# Altium schematic sheet charger.SchDoc
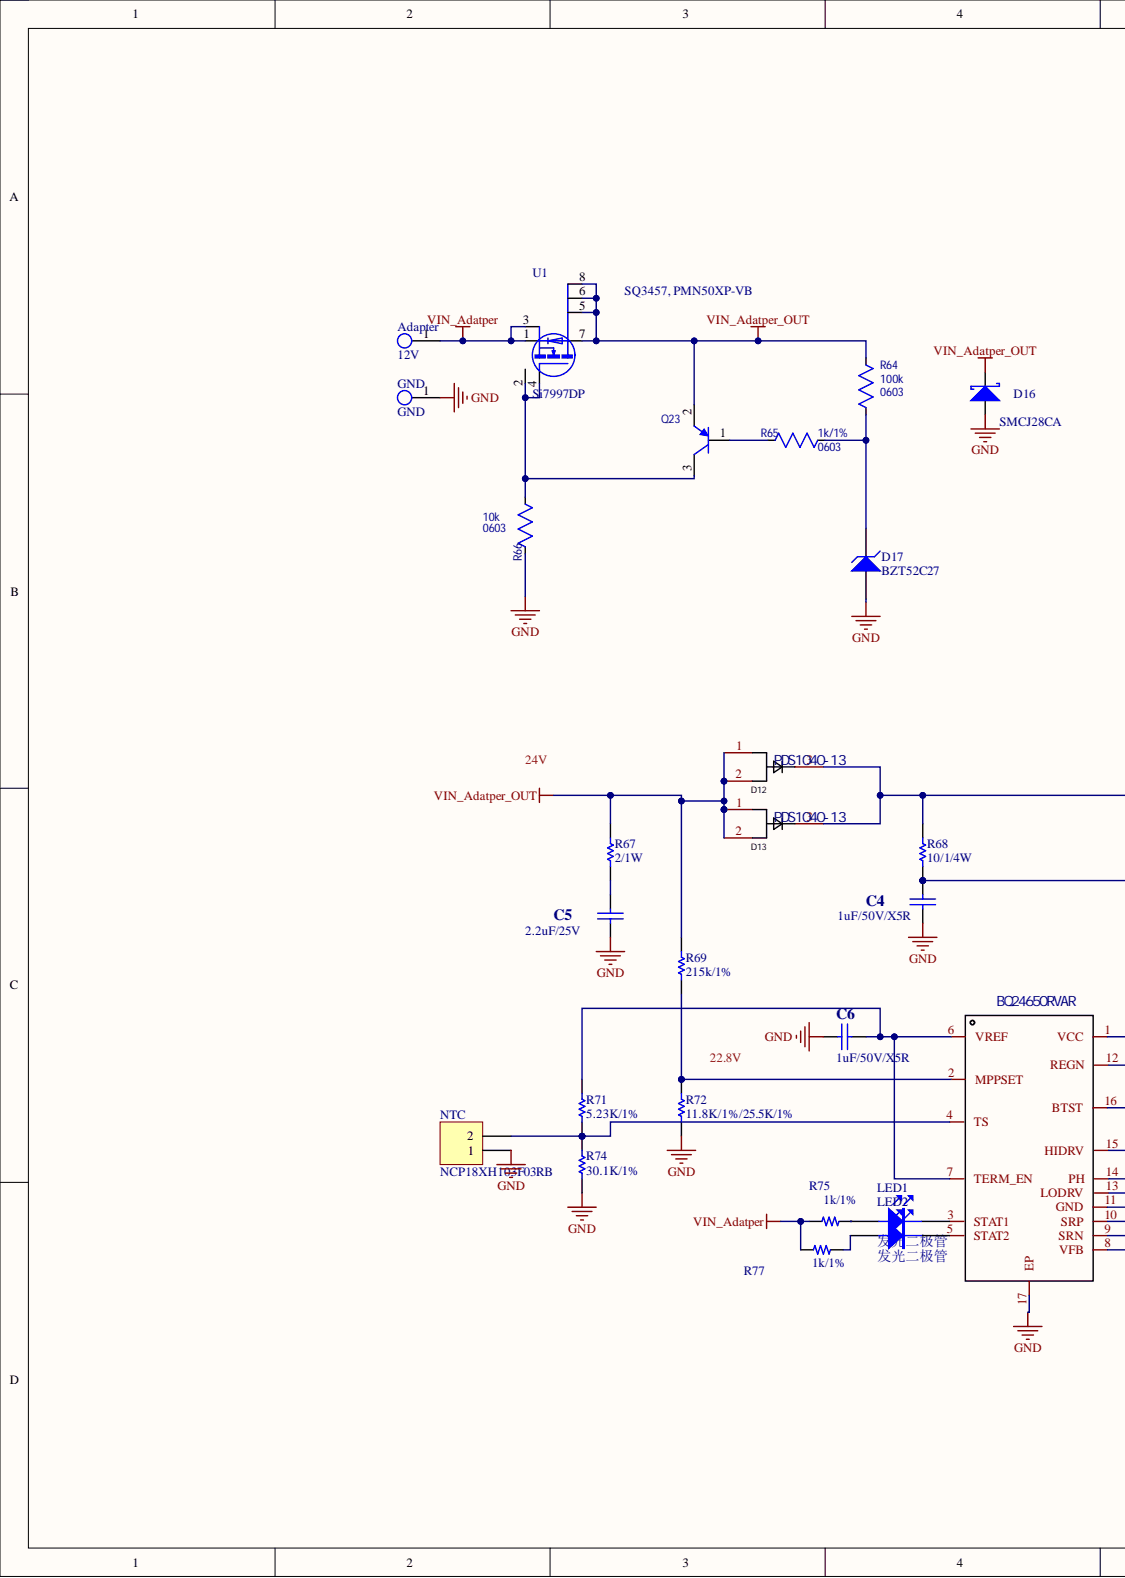
[diagram p0: charger.SchDoc, left]
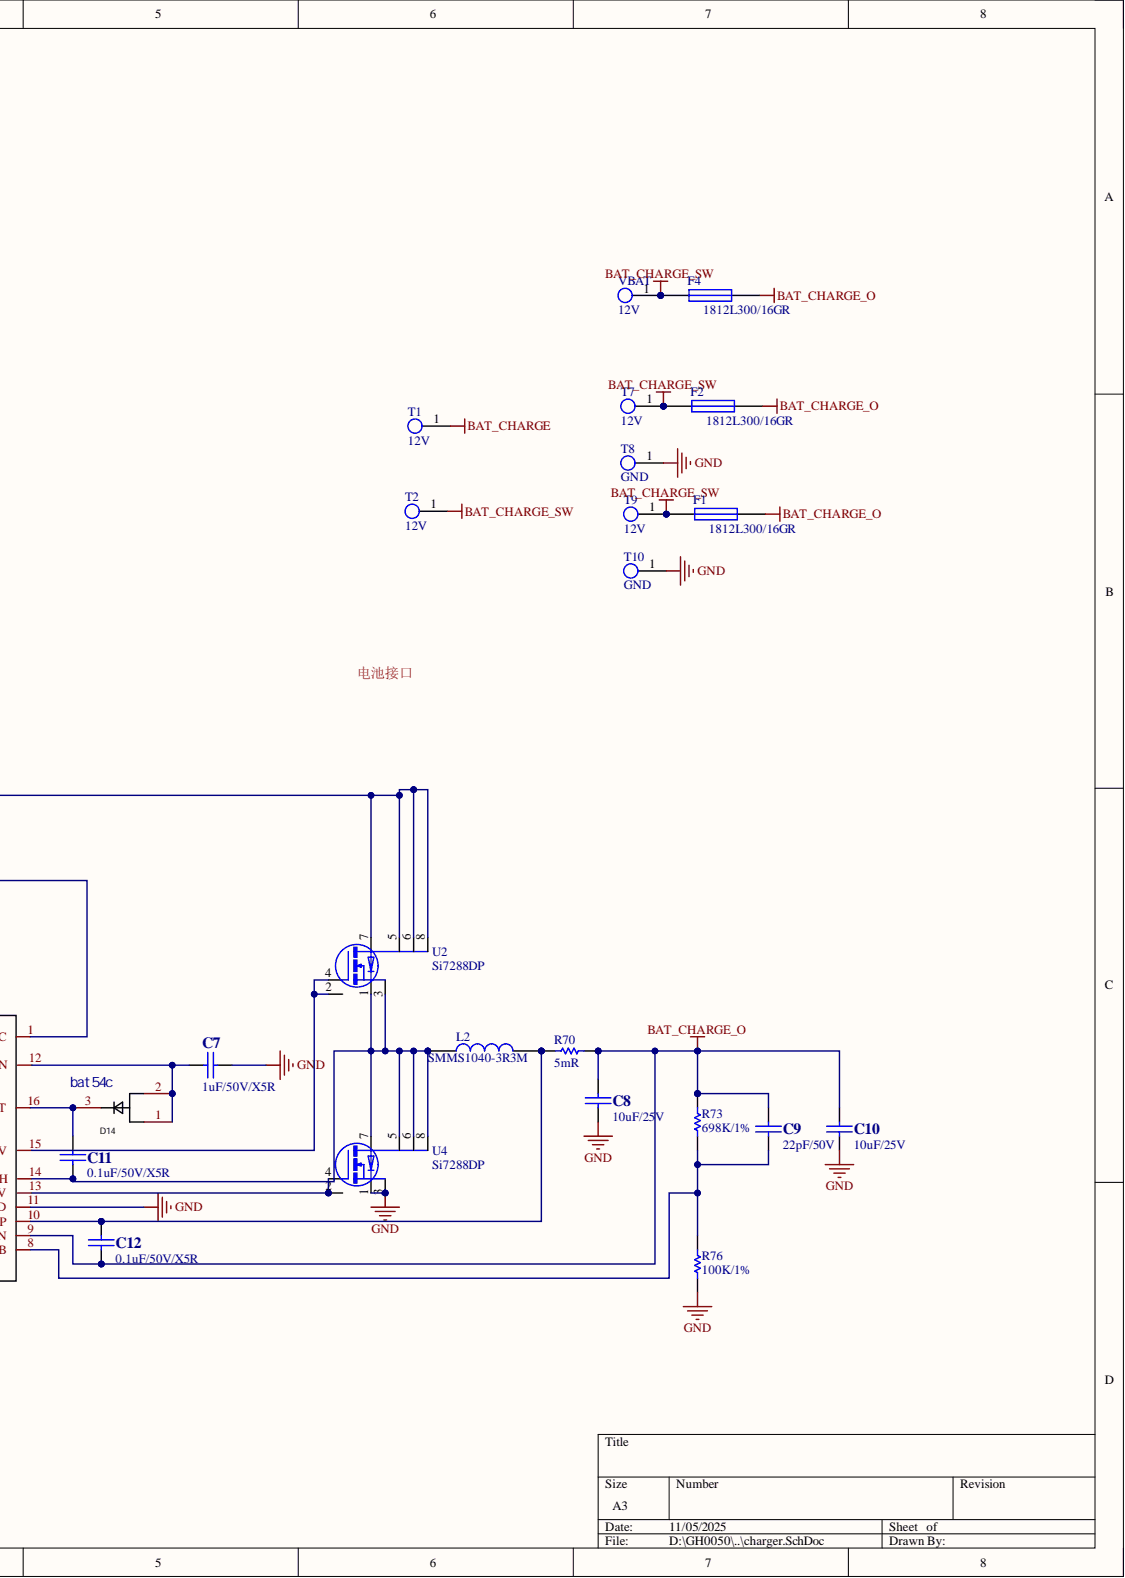
[diagram p1: charger.SchDoc, right]

## Parts
Adapter  12V  [FIX-4.5]  (no BOM)
C4  1uF/50V/X5R  [0805C]
C5  2.2uF/25V  [1210C]
C6  1uF/50V/X5R  [0603C]
C7  1uF/50V/X5R  [0603C]
C8  10uF/25V  [0603C]
C9  22pF/50V  [0603C]
C10  10uF/25V  [0603C]
C11  0.1uF/50V/X5R  [0603C]
C12  0.1uF/50V/X5R  [0603C]
D12  PDS1040-13  [POWERDI-5_L5.4-W4.0-LS6.5-RD]
D13  PDS1040-13  [POWERDI-5_L5.4-W4.0-LS6.5-RD]
D14  bat54c  [sot23-3]
D16  SMCJ28CA  [SMC]
D17  BZT52C27  [sod123]
F1  1812L300/16GR  [1812-F]
F2  1812L300/16GR  [1812-F]
F4  1812L300/16GR  [1812-F]
GND  GND  [FIX-4.5]  (no BOM)
L2  SMMS1040-3R3M  [MSS1038]
LED1  发光二极管  [0603LED]
LED2  发光二极管  [0603LED]
NTC  NCP18XH103F03RB  [HDR1X2]
Q23  MMBT4403-7-F  [SOT23-3]  (value not drawn)
R64  value 100k  Package: 0603  [0603R]  (hidden comment: 100K/1%)
R65  1k/1%  Package: 0603  [0603R]
R66  10k  Package: 0603  [0603R]
R67  2/1W  [2512R]
R68  10/1/4W  [1206R]
R69  215k/1%  [0603R]
R70  5mR  [2512R]
R71  5.23K/1%  [0603R]
R72  11.8K/1%/25.5K/1%  [0603R]
R73  698K/1%  [0603R]
R74  30.1K/1%  [0603R]
R75  1k/1%  [0603R]
R76  100K/1%  [0603R]
R77  1k/1%  [0603R]
T1  12V  [FIX-4.5]  (no BOM)
T2  12V  [FIX-4.5]  (no BOM)
T7  12V  [FIX-4.5]  (no BOM)
T8  GND  [FIX-4.5]  (no BOM)
T9  12V  [FIX-4.5]  (no BOM)
T10  GND  [FIX-4.5]  (no BOM)
U1  Si7997DP  [PowerPAK SO-8]
U2  Si7288DP  [PowerPAK SO-8]
U4  Si7288DP  [PowerPAK SO-8]
U5  BQ24650RVAR  [VQFN-16_L3.6-W3.6-P0.50-BL-EP]
VBAT  12V  [FIX-4.5]  (no BOM)

## Nets
BAT_CHARGE: T1.1
BAT_CHARGE_O: C8.2 C9.2 C10.2 C12.1 F1.2 F2.2 F4.2 R70.2 R73.1 U5.9(SRN)
BAT_CHARGE_SW: F1.1 F2.1 F4.1 T2.1 T7.1 T9.1 VBAT.1
GND: C4.2 C5.2 C6.1 C7.2 C8.1 C10.1 D16.1 D17.1 GND.1 NTC.1 R66.2 R72.2 R74.2 R76.2 T8.1 T10.1 U4.1 U4.3 U5.11(GND) U5.17(EP)
VIN_Adatper: Adapter.1 R75.2 R77.2 U1.1 U1.3
VIN_Adatper_OUT: D12.1 D12.2 D13.1 D13.2 D16.2 Q23.2 R64.2 R67.1 R69.1 U1.5 U1.6 U1.7 U1.8
NetC4_1: C4.1 R68.2 U5.1(VCC)
NetC5_1: C5.1 R67.2
NetC6_2: C6.2 R71.1 U5.6(VREF) U5.7(TERM_EN)
NetC7_1: C7.1 D14.1 D14.2 U5.12(REGN)
NetC9_1: C9.1 R73.2 R76.1 U5.8(VFB)
NetC11_1: C11.1 L2.1 U2.1 U2.3 U4.5 U4.6 U4.7 U4.8 U5.14(PH)
NetC11_2: C11.2 D14.3 U5.16(BTST)
NetC12_2: C12.2 L2.2 R70.1 U5.10(SRP)
NetD12_3: D12.3 D13.3 R68.1 U2.5 U2.6 U2.7 U2.8
NetD17_2: D17.2 R64.1 R65.1
NetLED1_1: LED1.1 R75.1
NetLED1_2: LED1.2 U5.3(STAT1)
NetLED2_1: LED2.1 R77.1
NetLED2_2: LED2.2 U5.5(STAT2)
NetNTC_2: NTC.2 R71.2 R74.1 U5.4(TS)
NetQ23_1: Q23.1 R65.2
NetQ23_3: Q23.3 R66.1 U1.2 U1.4
NetR69_2: R69.2 R72.1 U5.2(MPPSET)
NetU2_2: U2.2 U2.4 U5.15(HIDRV)
NetU4_2: U4.2 U4.4 U5.13(LODRV)

## Library data of the parts
Adapter GND T1 T2 T7-T10 VBAT = TP: Library Name: Schlib_20110825.schlib
C4-C12 = CAP: Capacitor; Component Kind: Standard
D12-D14 = PDS1040-13: Manufacturer: DIODES(美台); Add into BOM: yes; Average Rectified Current (Io): 10A; Forward Voltage (Vf@If): 510mV@10A; Reverse Voltage (Vr): 40V; Component Kind: Standard; Convert to PCB: yes; Datasheet: https://atta.szlcsc.com/upload/public/pdf/source/20170427/1493286399327.pdf; Device: PDS1040-13; JLCPCB Part Class: Extended Part; LCSC Part Name: 电压:40V 电流:10A; Library Name: Schlib_20110825.schlib; Manufacturer Part: PDS1040-13; Supplier: LCSC; Supplier Footprint: Power-DI-5; Supplier Part: C110512; Symbol: PDS1040-13
D16 = DIODE S: Schottky Diode; Component Kind: Standard
D17 = BZT52C12S-7-F: Pd - Power Dissipation: 200mW; Unit Weight: 0.000173oz; Voltage Temperature Coefficient: 0.25mV/C; Voltage Tolerance: 5%; Vz - Zener Voltage: 12V; Zener Current: 5mA; Zz - Zener Impedance: 40Ohms; Component Kind: Standard; Minimum Operating Temperature: -65C; Mounting Style: SMD/SMT; Mounting Technology: SurfaceMount; Package / Case: SOD-323; Package Description: 2-Pin Surface Mount Device, Body 1.7 x 1.3 mm; Package Reference: SOD323-2; Package URL: http://www.vishay.com/docs/71192/71192.pdf; Packaging: Reel; Product Category: ZenerDiodes; RoHS: True; Series: BZT52C12; Termination Style: SMD/SMT; Value: BZT52C12S-7-F; Width: 1.4mm; Library Name: Bogen.SchLib
F1 F2 F4 = Fuse 1: Fuse; Component Kind: Standard; LatestRevisionDate: 17-Jul-2002; LatestRevisionNote: Re-released for DXP Platform.; Library Name: Miscellaneous Devices.IntLib; PackageDescription: Thru-Hole; 2 Leads; PackageReference: PIN-W2/E2.8; Published: 8-Jun-2000; Simulation: FUSE; Publisher: Altium Limited
L2 = INDUCTOR: Component Kind: Standard
LED1 LED2 = LED: Component Kind: Standard
NTC = Header 2: XH2.54 2P 立贴; Component Kind: Standard; LatestRevisionDate: 17-Jul-2002; LatestRevisionNote: Re-released for DXP Platform.; Library Name: Miscellaneous Connectors.IntLib; Signal Integrity: Connector; Publisher: Altium Limited
Q23 = OEM_Diodes_MMBT4403: Component Kind: Standard; Value: MMBT4403-7-F; Library Name: Karl.SchLib
R64 = Resistor_0603: PartNumber: RC0603FR-0716K9L; Power Rating: [power]; TempCo: [tempco]; Tolerance: tol; Component Kind: Standard; SupplierDescription: RES SMD 16.9K OHM 1% 1/10W 0603; Library Name: Karl.SchLib
R65 R66 = Resistor_0603: PartNumber: RC0603FR-071KL; Power Rating: [power]; TempCo: [tempco]; Tolerance: tol; Component Kind: Standard; SupplierDescription: RES SMD 1K OHM 1% 1/10W 0603; Library Name: Karl.SchLib
  R65: Value: 1k/1%
  R66: Value: 10k
R67-R74 R76 = Res1: Resistor; Component Kind: Standard; LatestRevisionDate: 17-Jul-2002; LatestRevisionNote: Re-released for DXP Platform.; Library Name: Miscellaneous Devices.IntLib; PackageReference: AXIAL-0.3; Published: 8-Jun-2000; Signal Integrity: Res; Simulation: RESISTOR; Publisher: Altium Limited
R75 R77 = R: Component Kind: Standard; Value: 1k/1%; Library Name: JRJ_1.SCHLIB
U1 U2 U4 = Si7997DP: Sia430DJ; Component Kind: Standard; Library Name: Schlib_20110825.schlib
U5 = BQ24650RVAR: Manufacturer: TI(德州仪器); Add into BOM: yes; Component Kind: Standard; Convert to PCB: yes; Datasheet: https://www.ti.com/cn/lit/gpn/bq24650; Device: BQ24650RVAR; JLCPCB Part Class: Extended Part; LCSC Part Name: BQ24650RVAR; Library Name: Schlib_20110825.schlib; Manufacturer Part: BQ24650RVAR; Supplier: LCSC; Supplier Footprint: VQFN-16-EP(3.6x3.6); Supplier Part: C53712; Symbol: BQ24650RVAR
